# Altium schematic sheet pedala.SchDoc(pedala)
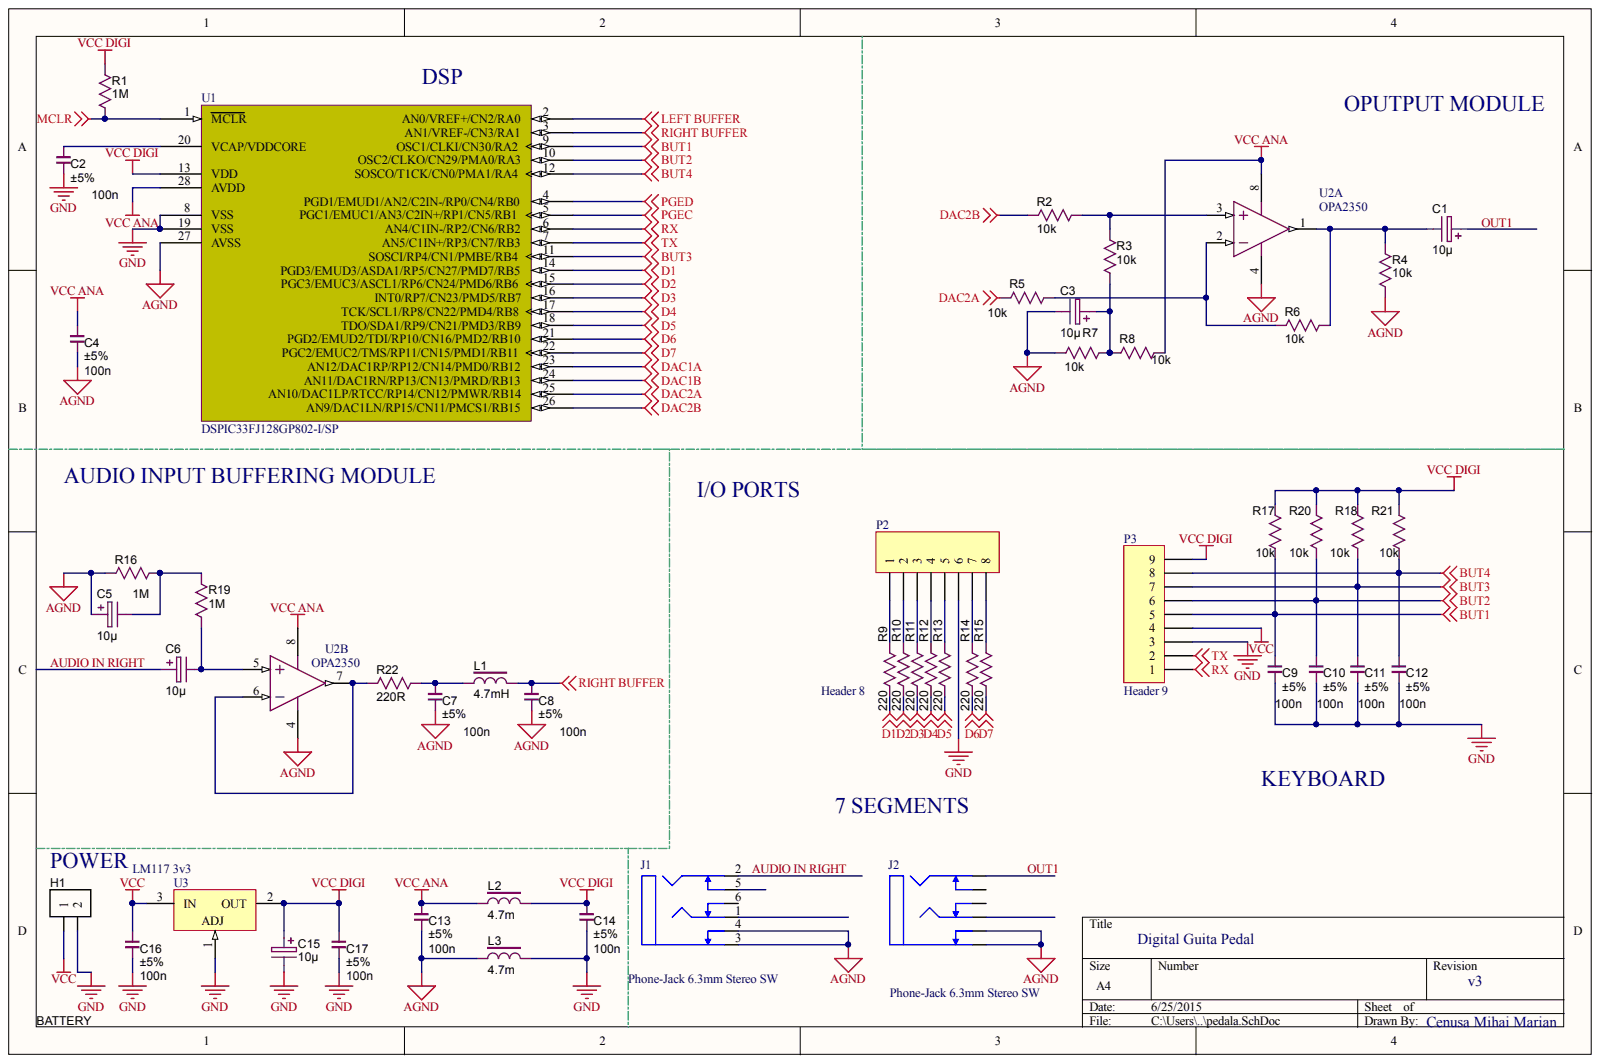
[diagram p0: pedala.SchDoc]

## Parts
C1  10µ  [CAPAE250P680X1220_VERT]
C2  100n  Tolerance: ±5%  [Cap-Disk-D5.5-R2.54]
C3  10µ  [CAPAE250P680X1220_VERT]
C4  100n  Tolerance: ±5%  [Cap-Disk-D5.5-R2.54]
C5  10µ  [CAPAE250P680X1220_VERT]
C6  10µ  [CAPAE250P680X1220_VERT]
C7  100n  Tolerance: ±5%  [Cap-Disk-D5.5-R2.54]
C8  100n  Tolerance: ±5%  [Cap-Disk-D5.5-R2.54]
C9  100n  Tolerance: ±5%  [Cap-Disk-D5.5-R2.54]
C10  100n  Tolerance: ±5%  [Cap-Disk-D5.5-R2.54]
C11  100n  Tolerance: ±5%  [Cap-Disk-D5.5-R2.54]
C12  100n  Tolerance: ±5%  [Cap-Disk-D5.5-R2.54]
C13  100n  Tolerance: ±5%  [Cap-Disk-D5.5-R2.54]
C14  100n  Tolerance: ±5%  [Cap-Disk-D5.5-R2.54]
C15  10µ  [CAPAE250P680X1220_VERT]
C16  100n  Tolerance: ±5%  [Cap-Disk-D5.5-R2.54]
C17  100n  Tolerance: ±5%  [Cap-Disk-D5.5-R2.54]
H1  BATTERY  [HDR_1X2X276]  (no BOM)
J1  Phone-Jack 6.3mm Stereo SW  [PHONE-JACK STEREO SW 6.3MM]
J2  Phone-Jack 6.3mm Stereo SW  [PHONE-JACK STEREO SW 6.3MM]
L1  value 4.7mH  [Dr-CW8A]  (hidden comment: INDC_180u_Toroid)
L2  value 4.7m  [Dr-CW8A]  (hidden comment: INDC_180u_Toroid)
L3  value 4.7m  [Dr-CW8A]  (hidden comment: INDC_180u_Toroid)
P2  Header 8  [HDR1X8]
P3  Header 9  [HDR1X9]
R1  value 1M  [R0.25-300]  (hidden comment: Thick Film)
R2  value 10k  [R0.25-300]  (hidden comment: Thick Film)
R3  value 10k  [R0.25-300]  (hidden comment: Thick Film)
R4  value 10k  [R0.25-300]  (hidden comment: Thick Film)
R5  value 10k  [R0.25-300]  (hidden comment: Thick Film)
R6  value 10k  [R0.25-300]  (hidden comment: Thick Film)
R7  value 10k  [R0.25-300]  (hidden comment: Thick Film)
R8  value 10k  [R0.25-300]  (hidden comment: Thick Film)
R9  value 220  [R0.25-300]  (hidden comment: Thick Film)
R10  value 220  [R0.25-300]  (hidden comment: Thick Film)
R11  value 220  [R0.25-300]  (hidden comment: Thick Film)
R12  value 220  [R0.25-300]  (hidden comment: Thick Film)
R13  value 220  [R0.25-300]  (hidden comment: Thick Film)
R14  value 220  [R0.25-300]  (hidden comment: Thick Film)
R15  value 220  [R0.25-300]  (hidden comment: Thick Film)
R16  value 1M  [R0.25-300]  (hidden comment: Thick Film)
R17  value 10k  [R0.25-300]  (hidden comment: Thick Film)
R18  value 10k  [R0.25-300]  (hidden comment: Thick Film)
R19  value 1M  [R0.25-300]  (hidden comment: Thick Film)
R20  value 10k  [R0.25-300]  (hidden comment: Thick Film)
R21  value 10k  [R0.25-300]  (hidden comment: Thick Film)
R22  value 220R  [R0.25-300]  (hidden comment: Thick Film)
U1  DSPIC33FJ128GP802-I/SP  [DIP28-300]
U2  OPA2350  [DIL8-300a]  (parts A,B)
U3  LM117 3v3  [TO220-RB-650]

## Nets
AGND: C3.2 C4.1 C5.1 C7.1 C8.1 C13.1 J1.3 J1.4 J2.3 J2.4 L3.1 R4.2 R7.2 R16.2 U1.27(AVSS) U2.4
BUT1: C9.2 P3.5 R17.1 U1.9(OSC1/CLKI/CN30/RA2)
BUT2: C10.2 P3.6 R20.1 U1.10(OSC2/CLKO/CN29/PMA0/RA3)
BUT3: C11.2 P3.7 R18.1 U1.11(SOSCI/RP4/CN1/PMBE/RB4)
BUT4: C12.2 P3.8 R21.1 U1.12(SOSCO/T1CK/CN0/PMA1/RA4)
D1: R9.1 U1.14(PGD3/EMUD3/ASDA1/RP5/CN27/PMD7/RB5)
D2: R10.1 U1.15(PGC3/EMUC3/ASCL1/RP6/CN24/PMD6/RB6)
D3: R11.1 U1.16(INT0/RP7/CN23/PMD5/RB7)
D4: R12.1 U1.17(TCK/SCL1/RP8/CN22/PMD4/RB8)
D5: R13.1 U1.18(TDO/SDA1/RP9/CN21/PMD3/RB9)
D6: R14.1 U1.21(PGD2/EMUD2/TDI/RP10/CN16/PMD2/RB10)
D7: R15.1 U1.22(PGC2/EMUC2/TMS/RP11/CN15/PMD1/RB11)
DAC1A: U1.23(AN12/DAC1RP/RP12/CN14/PMD0/RB12)
DAC1B: U1.24(AN11/DAC1RN/RP13/CN13/PMRD/RB13)
DAC2A: R5.2 U1.25(AN10/DAC1LP/RTCC/RP14/CN12/PMWR/RB14)
DAC2B: R2.2 U1.26(AN9/DAC1LN/RP15/CN11/PMCS1/RB15)
GND: C2.1 C9.1 C10.1 C11.1 C12.1 C14.1 C15.2 C16.1 C17.1 H1.2 L3.2 P2.6 P3.3 U1.8(VSS) U1.19(VSS) U3.1
LEFT BUFFER: U1.2(AN0/VREF+/CN2/RA0)
MCLR: R1.1 U1.1(MCLR)
PGEC: U1.5(PGC1/EMUC1/AN3/C2IN+/RP1/CN5/RB1)
PGED: U1.4(PGD1/EMUD1/AN2/C2IN-/RP0/CN4/RB0)
RIGHT BUFFER: C8.2 L1.2 U1.3(AN1/VREF-/CN3/RA1)
RX: P3.1 U1.6(AN4/C1IN-/RP2/CN6/RB2)
TX: P3.2 U1.7(AN5/C1IN+/RP3/CN7/RB3)
VCC: C16.2 H1.1 P3.4 U3.3
VCC ANA: C4.2 C13.2 L2.1 R8.1 U1.28(AVDD) U2.8
VCC DIGI: C14.2 C15.1 C17.2 L2.2 P3.9 R1.2 R17.2 R18.2 R20.2 R21.2 U1.13(VDD) U3.2
AUDIO IN RIGHT: C6.1 J1.2
OUT1: C1.1 J2.2
NetC1_2: C1.2 R4.1 R6.1 U2.1
NetC2_2: C2.2 U1.20(VCAP/VDDCORE)
NetC3_1: C3.1 R3.1 R7.1 R8.2
NetC5_2: C5.2 R16.1 R19.1
NetC6_2: C6.2 R19.2 U2.5
NetC7_2: C7.2 L1.1 R22.2
NetP2_1: P2.1 R9.2
NetP2_2: P2.2 R10.2
NetP2_3: P2.3 R11.2
NetP2_4: P2.4 R12.2
NetP2_5: P2.5 R13.2
NetP2_7: P2.7 R14.2
NetP2_8: P2.8 R15.2
NetR2_1: R2.1 R3.2 U2.3
NetR5_1: R5.1 R6.2 U2.2
NetR22_1: R22.1 U2.6 U2.7

Not connected: J1 1 5 6; J2 1 5 6

## Library data of the parts
C1 C3 C5 C6 C15 = CAPT_330u_2917_6V3: Capacitor SMD Tant 330uF 20% 6V3 2917; Manufacturer Part Number: T520D337M006ATE015; Manufacturer Size Code: D; ESR (Equivalent Series Resistance): 15 mOhm; Material: Solid Tantalum; Ratings: 6.3V; SCDType: spec; Component Kind: Standard; ComponentLink1Description: T520D Datasheet; ComponentLink1URL: http://www.kemet.com/datasheets&T520D337M006ATE015; Height - Seated (Max): 0.122" (3.10mm); Operating Temperature: -55°C ~ 125°C; Package / Case: 2917 (7343 Metric); Size / Dimension: 0.287" L x 0.169" W (7.30mm x 4.30mm); Supplier 1: Digi-Key; Supplier Part Number 1: 399-4052-1-ND; Tolerance: ±20%; Value: 10µ; Library Name: pedala.SCHLIB
C2 C4 C7-C14 C16 C17 = CAPC_100n_1206_NP0_50V_5%: Capacitor SMD Cer 100nF 1206 NP0 50V 5%; Manufacturer 1: TDK Corporation; Manufacturer Part Number 1: C3216C0G1H104J160AA; Ratings: 50V; NP0; SCDType: undef; Component Kind: Standard; ComponentLink1Description: http://product.tdk.com/capacitor/mlcc/en/documents/mlcc_commercial_general_en.pdf; ComponentLink1URL: http://product.tdk.com/capacitor/mlcc/en/documents/mlcc_commercial_general_en.pdf; Operating Temperature: -55°C ~ 125°C; Package / Case: 1206 (3216 Metric); Size / Dimension: 0.126" L x 0.063" W (3.20mm x 1.60mm); Supplier 1: Digi-Key; Supplier Part Number 1: 445-7694-1-ND; Thickness (Max): 0.075" (1.90mm); Value: 100n; Library Name: pedala.SCHLIB
H1 = Header2P: Header, 2-pin; Library Name: pedala_2.SCHLIB
L1-L3 = INDC_180u_Toroid: Power Inductor 180µH 10% SMD Toroid; Manufacturer 1: JW Miller A Bourns Company; Manufacturer Part Number 1: PM2110-181K-RC; Current: 3.7A; SCDType: spec; Component Kind: Standard; Package / Case: 1.200" L x 1.000" W x 0.530" H; Ratings: 3.7A; Supplier 1: Digi-Key; Supplier Part Number 1: M8769-ND; Tolerance: ±10%; Library Name: pedala.SCHLIB
P2 = Header 8: Header, 8-Pin; Component Kind: Standard; LatestRevisionDate: 17-Jul-2002; LatestRevisionNote: Re-released for DXP Platform.; Library Name: Miscellaneous Connectors.IntLib; Publisher: Altium Limited
P3 = Header 9: Header, 9-Pin; Component Kind: Standard; LatestRevisionDate: 17-Jul-2002; LatestRevisionNote: Re-released for DXP Platform.; Library Name: Miscellaneous Connectors.IntLib; Publisher: Altium Limited
R1-R22 = RES_1M_0805_5%: Resistor SMD chip 1M Ohm 0.125W 5% 0805; Manufacturer 1: Vishay/Dale; Manufacturer Part Number 1: CRCW08051M00JNEA; Ratings: 1/8W; SCDType: spec; Component Kind: Standard; Package / Case: 0805 (2012 metric); Supplier 1: Digi-Key; Supplier Part Number 1: 541-1.0MACT-ND; Tolerance: ±5%; Library Name: pedala.SCHLIB
  R16: Value: 1M
U1 = DSPIC33FJ128GP802-I/SP: High-Performance 16-bit Digital Signal Controller, 128 KB Flash, 16 KB RAM, 28-Pin SPDIP, Industrial Temperature; Manufacturer: Microchip; Component Kind: Standard; ComponentLink1Description: Manufacturer URL; ComponentLink1URL: http://www.microchip.com/; ComponentLink2Description: Datasheet; ComponentLink2URL: http://ww1.microchip.com/downloads/en/DeviceDoc/70292D.pdf; ComponentLink3Description: Package Specification; ComponentLink3URL: http://www.microchip.com/stellent/groups/techpub_sg/documents/packagingspec/en012702.pdf; DatasheetVersion: revD, Nov-2009; Mounting Technology: Through Hole; PackageDescription: 28-Lead Skinny Plastic Dual In-Line (SP) - 300 mil Body [SPDIP]; PackageReference: SPDIP300-SP28; PackageVersion: revBB, Aug-2009; PartNumber: DSPIC33FJ128GP802-I/SP; Supplier 1: Digi-Key; Supplier 2: Farnell; Supplier 3: Newark; Supplier 4: Mouser; Supplier Part Number 1: DSPIC33FJ128GP802-I/SP-ND; Supplier Part Number 2: 1576801; Supplier Part Number 3: 39M8119; Supplier Part Number 4: 579-DSPIC128GP802ISP; Library Name: pedala.SCHLIB
U2 = OPA2313ID: 1-MHz, Micropower, Low-Noise, Rail-to-Rail I/O Operational Amplifier, 1.8 to 5.5 V, -40 to 125 degC, 8-pin SOIC (D8), Green (RoHS & no Sb/Br); Manufacturer: Texas Instruments; CMRR(Min)(dB): 70; GBW(Typ)(MHz): 1; Iq per channel(Max)(mA): 0.06; Number of Channels: 2; Packing: TUBE; Rating: Catalog; Slew Rate(Typ)(V/us): 0.5; SubFamily: Low Input Bias Current Amp (<=10pA), Low Power Amp (<=500uA); Total Supply Voltage(Max)(+5V is 5, +/-5V is 10): 5.5; Total Supply Voltage(Min)(+5V is 5, +/-5V is 10): 1.8; Vio (25C)(Max)(mV): 2.5; Code_JEDEC: MS-012; Component Kind: Standard; ComponentLink1Description: Manufacturer URL; ComponentLink1URL: http://www.ti.com/; ComponentLink2Description: Datasheet; ComponentLink2URL: http://www.ti.com/lit/ds/symlink/opa2313.pdf; ComponentLink3Description: Package Specification; ComponentLink3URL: http://www.ti.com/litv/pdf/msoi002j; DatasheetVersion: SBOS649C; Eco-Plan: Green (RoHS & no Sb/Br); Mounting Technology: Surface Mount; Offset Drift(Typ)(uV/C): 2; PackageDescription: 8-Pin Plastic Small Outline, Body 4.9 x 3.9 mm, Pitch 1.27 mm; PackageReference: D8; PackageVersion: 4040047-3/M, 06/2011; PartNumber: OPA2313ID; Supplier 1: Digi-Key; Supplier 2: Newark; Supplier 3: Mouser; Supplier Part Number 1: 296-35005-ND; Supplier Part Number 2: 47W7351; Supplier Part Number 3: 595-OPA2313ID; Library Name: pedala.SCHLIB
U3 = TL317CLP: Adjustable Regulator with 5 to 38 V Input and 1.2 to 32 V Output, 0 to 125 degC, 3-Pin TO-92 (LP), Pb-Free (RoHS); Manufacturer: Texas Instruments; Iout(Max)(A): 0.1; SubFamily: Single Channel LDO; Vin(Max)(V): 38; Vin(Min)(V): 5; Vout(Max)(V): 32; Vout(Min)(V): 1.2; Code_JEDEC: TO-226-AA; Component Kind: Standard; ComponentLink1Description: Datasheet; ComponentLink1URL: http://www.ti.com/general/docs/lit/getliterature.tsp?genericPartNumber=TL317&fileType=pdf; ComponentLink2Description: Package Specification; ComponentLink2URL: http://www.ti.com/litv/pdf/msot002a; ComponentLink3Description: URL Link1; ComponentLink3URL: Samples URL:http://www.ti.com/product/tl317#samples; DatasheetVersion: SLVS004H; Eco-Plan: Pb-Free (RoHS); Library Name: TI Power Management Standard Voltage Regulator.IntLib; Mounting Technology: Through Hole; PackageDescription: Plastic Cylindrical Package, Formed Lead Option (TO-92); PackageReference: LP3; PackageVersion: revC, Oct-2001; PartNumber: TL317CLP; Supplier 1: Digi-Key; Supplier 2: Farnell; Supplier 3: Newark; Supplier 4: Mouser; Supplier Part Number 1: 296-10167-5-ND; Supplier Part Number 2: 9593519; Supplier Part Number 3: 08F9402; Supplier Part Number 4: 595-TL317CLP
J1 J2 = Phone-Jack 6.3mm Stereo SW: Component Kind: Standard; Library Name: Connektor.IntLib
